# Altium schematic sheet JW302-01-V01_A0.SchDoc (continued)

## Library data of the parts
B1-B4 = 贴片磁珠 0603 600Ω@100MHz ±25% 1.3A 150mΩ: 贴片磁珠; 参考单价: 0.043424; 品牌: muRata(村田); 型号: BLM18KG601SN1D; Component Kind: Standard; Supplier Part: C85833; Library Name: 常用电阻电容库-20240318.SchLib
C1 C7 C12 = 贴片电容 0402 10uF ±20% 10V X5R: 贴片电容; 参考单价: 0.0457; 品牌: 村田; 型号: GRM155R61A106ME44D; 替换料: C408132: GRM155R61A106ME11D; Component Kind: Standard; Supplier Part: C77000; Library Name: 常用电阻电容库-20240318.SchLib
C2 C5 C8 C14 C16 C18 C20 C23 C27-C29 C39 C41 C52 C55 C62 C68 = 贴片电容 0402 100nF ±10% 50V X7R: 贴片电容; 参考单价: 0.010063; 品牌: 村田; 型号: GRM155R71H104KE14D; Component Kind: Standard; Supplier Part: C77020
  C29 C39 C41 C52 C55 C62: Library Name: LCR库-20240318.SchLib
  C2 C5 C8 C14 C16 C18 C20 C23 C27 C28 C68: Library Name: 常用电阻电容库-20240318.SchLib
C3 = 贴片电容 0402 1.8pF ±0.1pF 50V C0G: 贴片电容; 参考单价: 0.081481; 品牌: 村田; 型号: GJM1555C1H1R8BB01D; Component Kind: Standard; Library Name: LCR库-20240318.SchLib; Supplier Part: C76902
C4 = 贴片电容 0402 1.5pF ±0.1pF 50V C0G: 贴片电容; 参考单价: 0.0553; 品牌: 村田; 型号: GJM1555C1H1R5BB01D; Component Kind: Standard; Library Name: LCR库-20240318.SchLib; Supplier Part: C76900
C6 C22 C53 = 贴片电容 0603 22uF ±20% 10V X5R: 贴片电容; 参考单价: 0.060427; 品牌: muRata(村田); 型号: GRM188R61A226ME15D; 替代料: C86295：CL10A226MP8NUNE; Component Kind: Standard; Supplier Part: C84419; Library Name: 常用电阻电容库-20240318.SchLib
C9 C63 = 贴片电容 0402 4.7uF ±10% 10V X5R: 贴片电容; 参考单价: 0.051144; 品牌: 村田; 型号: GRM155R61A475KEAAD; Component Kind: Standard; Library Name: LCR库-20240318.SchLib; Supplier Part: C77004
C10 C11 = 贴片电容 0402 15pF ±5% 50V C0G: 贴片电容; 参考单价: 0.0085; 品牌: 村田; 型号: GRM1555C1H150JA01D; Component Kind: Standard; Library Name: LCR库-20240318.SchLib; Supplier Part: C76950
C13 C15 C25 C35 C36 C59 = 贴片电容 0402 1uF ±10% 25V X5R: 贴片电容; 参考单价: 0.0248; 品牌: 村田; 型号: GRM155R61E105KA12D; Component Kind: Standard; Supplier Part: C77009
  C35 C36 C59: Library Name: LCR库-20240318.SchLib
  C13 C15 C25: Library Name: 常用电阻电容库-20240318.SchLib
C17 C66 C67 = 贴片电容 0402 10nF ±10% 50V X7R: 贴片电容; 参考单价: 0.008376; 品牌: 村田; 型号: GRM155R71H103KA88D; Component Kind: Standard; Supplier Part: C77019; Library Name: 常用电阻电容库-20240318.SchLib
C19 = 贴片电容 0603 10uF ±20% 35V X5R: 贴片电容; 参考单价: 0.133331; 品牌: muRata(村田); 型号: GRM188R6YA106MA73D; Component Kind: Standard; Supplier Part: C194427; Library Name: 常用电阻电容库-20240318.SchLib
C21 = 贴片电容 1206 100uF ±20% 6.3V X5R: 贴片电容; 参考单价: 0.3025; 品牌: SAMSUNG(三星); 型号: CL31A107MQHNNNE; Component Kind: Standard; Library Name: LCR库-20240318.SchLib; Supplier Part: C15008
C24 = 贴片电容 0402 470pF ±5% 50V C0G: 贴片电容; 参考单价: 0.012183; 品牌: 村田; 型号: GRM1555C1H471JA01D; Component Kind: Standard; Supplier Part: C76971; Library Name: 常用电阻电容库-20240318.SchLib
C26 = 贴片电容 0402 2.2uF ±10% 10V X5R: 贴片电容; 参考单价: 0.024506; 品牌: 村田; 型号: GRM155R61A225KE95D; Component Kind: Standard; Supplier Part: C77002; Library Name: 常用电阻电容库-20240318.SchLib
C30 C42 = 贴片电容 0402 22pF ±5% 50V C0G: 贴片电容; 参考单价: 0.008376; 品牌: 村田; 型号: GRM1555C1H220JA01D; Component Kind: Standard; Supplier Part: C76960; Library Name: 常用电阻电容库-20240318.SchLib
C31 C32 C47 C48 C60 = 贴片电容 0603 10uF ±10% 16V X5R: 贴片电容; 参考单价: 0.1745; 品牌: muRata(村田); 型号: GRM188R61C106KAALD; Component Kind: Standard; Library Name: LCR库-20240318.SchLib; Supplier Part: C86275，C711098
C33 C34 C49 C50 = 贴片电容 0603 22uF ±20% 10V X5R: 贴片电容; 参考单价: 0.060427; 品牌: muRata(村田); 型号: GRM188R61A226ME15D; 替换料: C86295：CL10A226MP8NUNE; Component Kind: Standard; Library Name: LCR库-20240318.SchLib; Supplier Part: C84419
C37 C38 C43-C46 C54 = 贴片电容 0402 1uF ±10% 10V X7S: 贴片电容; 参考单价: 0.058339; 品牌: 村田; 型号: GCM155C71A105KE38D; Component Kind: Standard; Library Name: LCR库-20240318.SchLib; Supplier Part: C126521
C40 C51 = 贴片电容 0402 10uF ±20% 10V X5R: 贴片电容; 参考单价: 0.0457; 品牌: 村田; 型号: GRM155R61A106ME44D; 替代料: C408132: GRM155R61A106ME11D; Component Kind: Standard; Library Name: LCR库-20240318.SchLib; Supplier Part: C77000
C56-C58 C61 C64 C65 = 贴片电容 1206 22uF ±10% 16V X5R: 贴片电容; 参考单价: 0.123884; 品牌: SAMSUNG(三星); 型号: CL31A226KOHNNNE; Component Kind: Standard; Library Name: LCR库-20240318.SchLib; Supplier Part: C90146
CARD1 = TF-021B-H265 抽拉式 MicroSD卡(TF卡) 卡座: TF卡座; Manufacturer: SOFNG(硕方); Add into BOM: yes; Card Connection Mode: 拔插式; Connector Type: 卡座; Maximum Body Height: 2.5mm; 参考单价: 0.737352; Card Type: MicroSD卡(TF卡); Component Kind: Standard; Convert to PCB: yes; Datasheet: https://atta.szlcsc.com/upload/public/pdf/source/20200318/C498185_790264C8C4D0E25CCBD64FEBD13A33FF.pdf; Device: TF-021B-H265; JLCPCB Part Class: Extended Part; LCSC Part Name: 拔插式 MicroSD卡(TF卡) 卡座; Manufacturer Part: TF-021B-H265; Operating Temperature Range: -25℃~+75℃; Supplier: LCSC; Supplier Footprint: SMD; Supplier Part: C498185; Symbol: TF-021B-H265; 品牌: SOFNG(硕方); 型号: TF-021B-H265; Library Name: JTL-20240318.SchLib
CH+ CH+. CH- CH-. HOT+ HOT- NTC1 NTC2 NTC- = 1PIN PAD: 引线焊盘; Component Kind: Standard; Library Name: JTL-20240318.SchLib
CN1 = 排线座 1.0-5P WT SH系列 1x5P 卧贴: 排线端子座; Manufacturer: SHOU HAN(首韩); Add into BOM: yes; Number of PINs Per Row: 5; Pins Structure: 1x5P; Pitch: 1mm; 参考单价: 0.18202; Component Kind: Standard; Convert to PCB: yes; Current Rating (Max): -; Datasheet: https://atta.szlcsc.com/upload/public/pdf/source/20211019/F6C8BB00F705446EDA229495354B330C.pdf; Device: 1.0-5P WT; JLCPCB Part Class: Extended Part; LCSC Part Name: 1.0-5P WT; Manufacturer Part: 1.0-5P WT; Mounting Style: 卧贴; Number of Pins: 5P; Number of Rows: 1; Operating Temperature Range: -; Reference Series: -; Row Spacing: -; Supplier: LCSC; Supplier Footprint: SMD,P=1mm,卧贴; Supplier Part: C2906271; Symbol: 1.0-5P WT; 品牌: SHOU HAN(首韩); 型号: 1.0-5P WT; Library Name: JTL-20240318.SchLib
CN2 = PAD_4PIN: 贴片插座; Value: MX1.25-3P 卧式; Component Kind: Standard; Library Name: JTL-20240318.SchLib
CN3 = 排线座  ZX-MX1.25-3PWT MX系列 1x3P 卧贴: 排线端子座; Manufacturer: Megastar(兆星); Add into BOM: yes; Current Rating (Max): 1A; Number of PINs Per Row: 3; Pins Structure: 1x3P; Pitch: 1.25mm; 参考单价: 0.147155; Component Kind: Standard; Contact Material: 黄铜; Contact Plating: 锡; Convert to PCB: yes; Datasheet: https://atta.szlcsc.com/upload/public/pdf/source/20230619/F53A6BBA29708C54D1E94831F29660FB.pdf; Device: ZX-MX1.25-3PWT; LCSC Part Name: 1x3P 间距:1.25mm 卧贴; Manufacturer Part: ZX-MX1.25-3PWT; Mounting Style: 卧贴; Number of Pins: 3P; Number of Rows: 1; Operating Temperature Range: -25℃~+85℃; Reference Series: -; Row Spacing: -; Supplier: LCSC; Supplier Footprint: SMD,P=1.25mm,卧贴; Supplier Part: C7430469; Symbol: ZX-MX1.25-3PWT; 品牌: Megastar(兆星); 型号: ZX-MX1.25-3PWT; Library Name: JTL-20240318.SchLib
CN4 CN5 = 排线座 1.0-2P WT SH系列 1x2P 卧贴: 排线端子座; Manufacturer: SHOU HAN(首韩); Add into BOM: yes; Current Rating (Max): 500mA; Number of PINs Per Row: 2; Pins Structure: 1x2P; Pitch: 1mm; 参考单价: 0.13908; Component Kind: Standard; Convert to PCB: yes; Datasheet: https://atta.szlcsc.com/upload/public/pdf/source/20211019/B5D67F44D8FE6623BC62180783B545E4.pdf; Device: 1.0-2P WT; JLCPCB Part Class: Extended Part; LCSC Part Name: 1.0-2P WT; Manufacturer Part: 1.0-2P WT; Mounting Style: 卧贴; Number of Pins: 2P; Number of Rows: 1; Operating Temperature Range: -25℃~+85℃; Reference Series: -; Row Spacing: -; Supplier: LCSC; Supplier Footprint: SMD,P=1mm,卧贴; Supplier Part: C2906268; Symbol: 1.0-2P WT; 品牌: SHOU HAN(首韩); 型号: 1.0-2P WT; Library Name: JTL-20240318.SchLib
FPC1 = FPC连接器 AFC01-S22FCA-00 SMD,P=0.5mm,卧贴 翻盖式 下接 22P: FPC连接器; Manufacturer: JS(钜硕电子); Add into BOM: yes; FFC, FCB Thickness: 0.3mm; Locking Feature: 翻盖式; Number of Contacts: 22P; Pitch: 0.5mm; 参考单价: 0.3727; Component Kind: Standard; Contact Type: 下接; Convert to PCB: yes; Datasheet: https://atta.szlcsc.com/upload/public/pdf/source/20190816/C413942_2A72D1AC756EAE17EEDF756A339D4500.pdf; Device: AFC01-S22FCA-00; Height Above Board: 2mm; JLCPCB Part Class: Extended Part; LCSC Part Name: 间距:0.5mm P数:22P 翻盖式 下接; Manufacturer Part: AFC01-S22FCA-00; Mounting Type: 卧贴; Supplier: LCSC; Supplier Footprint: SMD,P=0.5mm,卧贴; Supplier Part: C262668; Symbol: AFC01-S22FCA-00; 品牌: JS(钜硕电子); 型号: AFC01-S22FCA-00; Library Name: JTL-20240318.SchLib
L1 = 贴片电感 0402 2.7nH ±0.3nH 800mA 100mΩ: 贴片电感; 参考单价: 0.045; 品牌: muRata(村田); 型号: LQG15HN2N7S02D; 替代料: C27123: SDCL1005C2N7STDF; Component Kind: Standard; Library Name: LCR库-20240318.SchLib; Supplier Part: C113054
L2 = 贴片电感 0603 10uH ±20% 100mA 1.365Ω: 贴片电感; 参考单价: 0.309131; 品牌: muRata(村田); 型号: LQM18DN100M70L; Component Kind: Standard; Library Name: LCR库-20240318.SchLib; Supplier Part: C713281
L3 L4 = 功率电感 FXL0420-4R7-M SMD,4.2x4.4mm 4.7uH ±20% 2.8A 3A 105mΩ: 功率电感; Manufacturer: cjiang(长江微电); Add into BOM: yes; DC Resistance (DCR): 105mΩ; Saturation Current (Isat): 3A; Tolerance: ±20%; Type: 一体成型电感; Value: 4.7uH; 参考单价: 0.3425; Component Kind: Standard; Convert to PCB: yes; Datasheet: https://atta.szlcsc.com/upload/public/pdf/source/20240426/3189EA8206330E2108542F9E34E540E7.pdf; Device: FXL0420-4R7-M; JLCPCB Part Class: Extended Part; LCSC Part Name: 4.7uH ±20% 3A; Library Name: LCR库-20240318.SchLib; Manufacturer Part: FXL0420-4R7-M; Supplier: LCSC; Supplier Footprint: SMD,4.2x4.4mm; Supplier Part: C167208; Symbol: FXL0420-4R7-M; 品牌: cjiang(长江微电); 型号: FXL0420-4R7-M
L5 = 功率电感 FXL1350-1R0-M SMD,12.6x13.5mm 1uH ±20% 28A 35A,2.2mΩ: 功率电感; Manufacturer: cjiang(长江微电); Add into BOM: yes; DC Resistance (DCR): 2.2mΩ; Rated Current: 26A; Tolerance: ±20%; Type: 一体成型电感; Value: 1uH; 参考单价: 1.73; Component Kind: Standard; Convert to PCB: yes; Datasheet: https://atta.szlcsc.com/upload/public/pdf/source/20240426/3189EA8206330E2108542F9E34E540E7.pdf; Device: FXL1350-1R0-M; JLCPCB Part Class: Extended Part; LCSC Part Name: 1uH ±20% 35A; Library Name: LCR库-20240318.SchLib; Manufacturer Part: FXL1350-1R0-M; Supplier: LCSC; Supplier Footprint: SMD,12.6x13.5mm; Supplier Part: C526011; Symbol: FXL1350-1R0-M; 品牌: cjiang(长江微电); 型号: FXL1350-1R0-M
NTC3 = NTC B57332V5103F360 0603 10KΩ±1%β(25/85)3435K,β(25/50)3380K: NTC; Manufacturer: TDK; Add into BOM: yes; B Constant (25℃/85℃): 3435K; B Constant (25℃/100℃): 3455K; B Constant Tolerance: ±1%; Power: 180mW; Resistance @ 25℃: 10kΩ; 参考单价: 0.232655; B Constant (25℃/75℃): -; Component Kind: Standard; Convert to PCB: yes; Datasheet: https://atta.szlcsc.com/upload/public/pdf/source/20180725/C209893_3E20A4FCEBCC841838CF028DBFDEBAEE.pdf; Device: B57332V5103F360; JLCPCB Part Class: Extended Part; LCSC Part Name: 10kΩ 电阻精度:±1% 3380K; Library Name: LCR库-20240318.SchLib; Manufacturer Part: B57332V5103F360; Operating Temperature: -40℃~+150℃; Resistance Tolerance: ±1%; Steady State Current (Max): -; Supplier: LCSC; Supplier Part: C209893; Symbol: B57332V5103F360; 品牌: TDK; 型号: B57332V5103F360
Q1-Q3 Q6 Q7 = PMOS SK2301AAT SOT-523-3 -20V,-2.1A,130mΩ@-4.5V -2.3A -620mV@-250uA: PMOS; Manufacturer: SHIKUES(时科); Add into BOM: yes; Continuous Drain Current (Id): 2.1A; Drain Source On Resistance (RDS(on)@Vgs,Id): 130mΩ@4.5V,2.3A; Drain Source Voltage (Vdss): 20V; Gate Threshold Voltage (Vgs(th)@Id): 620mV@250uA; Input Capacitance (Ciss@Vds): 290pF@10V; Power Dissipation (Pd): 350mW; Reverse Transfer Capacitance (Crss@Vds): 29pF@10V; Total Gate Charge (Qg@Vgs): 3.9nC@4.5V; Type: 1个P沟道; 参考单价: 0.132; Component Kind: Standard; Convert to PCB: yes; Datasheet: https://atta.szlcsc.com/upload/public/pdf/source/20231124/8C624ED955EAB991419DA0DB728EE27F.pdf; Device: SK2301AAT; JLCPCB Part Class: Extended Part; LCSC Part Name: 1个P沟道 耐压:20V 电流:2.1A; Manufacturer Part: SK2301AAT; Operating Temperature: -55℃~+150℃; Supplier: LCSC; Supplier Footprint: SOT-523; Supplier Part: C5334586; Symbol: SK2301AAT; 品牌: SHIKUES(时科); 型号: SK2301AAT; Library Name: JTL-20240318.SchLib
Q4 Q5 = NMOS JMSL0302AG DFN-5 30V,145A,1.2mΩ@10V 20A,1.7V@250uA: NMOS; Manufacturer: JJW(捷捷微); Add into BOM: yes; Continuous Drain Current (Id): 178A; Drain Source On Resistance (RDS(on)@Vgs,Id): 1.3mΩ@10V,20A; Drain Source Voltage (Vdss): 30V; Input Capacitance (Ciss@Vds): 2.975nF@15V; Power Dissipation (Pd): 78W; Reverse Transfer Capacitance (Crss@Vds): 117pF@15V; Total Gate Charge (Qg@Vgs): 39nC@10V; 参考单价: 0.9; Component Kind: Standard; Convert to PCB: yes; Datasheet: https://atta.szlcsc.com/upload/public/pdf/source/20210907/947B1805E145B198DD3F472E870FBC49.pdf; Device: JMSL0302AG; Gate Threshold Voltage (Vgs(th)@Id): 1.7V@250uA; JLCPCB Part Class: Extended Part; LCSC Part Name: N沟道,电流:178A,耐压:30V; Manufacturer Part: JMSL0302AG; Operating Temperature: -55℃~+150℃; Supplier: LCSC; Supplier Footprint: DFN-5(5.1x6.1); Supplier Part: C2890410; Symbol: JMSL0302AG; 品牌: JJW(捷捷微); 型号: JMSL0302AG; Library Name: JTL-20240318.SchLib
Q8 Q9 = NMOS CJ1012 SOT-523-3 20V,0.5A,700mΩ@4.5V 0.5A,1.2V@250uA: NMOS; Manufacturer: CJ(江苏长电/长晶); Add into BOM: yes; Continuous Drain Current (Id): 500mA; Drain Source Voltage (Vdss): 20V; Gate Threshold Voltage (Vgs(th)@Id): 1.2V; Input Capacitance (Ciss@Vds): 100pF; Reverse Transfer Capacitance (Crss@Vds): 12pF; Symbol: CJ1012_C2991967; Total Gate Charge (Qg@Vgs): 750nC; Type: 1个N沟道; 参考单价: 0.176; Component Kind: Standard; Convert to PCB: yes; Datasheet: https://atta.szlcsc.com/upload/public/pdf/source/20220411/AA10FFCA313DDFB0AC016DEA89735A25.pdf; Device: CJ1012; JLCPCB Part Class: Extended Part; LCSC Part Name: 1个N沟道 耐压:20V 电流:500mA; Manufacturer Part: CJ1012; Supplier: LCSC; Supplier Footprint: SOT-523; Supplier Part: C2991967; 品牌: CJ(江苏长电/长晶); 型号: CJ1012; Library Name: JTL-20240318.SchLib
R1 R2 R4 R6 R8 R43 R45 = 厚膜电阻 0402 4.7KΩ ±1%: 贴片电阻; 参考单价: 0.0023; 品牌: 国巨; 型号: RC0402FR-074K7L; Component Kind: Standard; Library Name: LCR库-20240318.SchLib; Supplier Part: C105871,C2935240
R3 R15-R17 R23-R25 R42 R46 R48 R62 = 厚膜电阻 0402 10KΩ ±1%: 贴片电阻; 参考单价: 0.003; 品牌: 国巨; 型号: RC0402FR-0710KL; Component Kind: Standard; Supplier Part: C60490; Library Name: 常用电阻电容库-20240318.SchLib
R5 R7 R9-R14 R26-R32 R49 R50 = 厚膜电阻 0402 0Ω ±1%: 贴片电阻; 参考单价: 0.003; 品牌: 国巨; 型号: RC0402FR-070RL; Component Kind: Standard; Library Name: LCR库-20240318.SchLib; Supplier Part: C106231
R18 = 厚膜电阻 0402 470Ω ±1%: 贴片电阻; 参考单价: 0.003; 品牌: 国巨; 型号: RC0402FR-07470RL; Component Kind: Standard; Library Name: LCR库-20240318.SchLib; Supplier Part: C114877
R19 R22 = 厚膜电阻 0402 30KΩ ±1%: 贴片电阻; 参考单价: 0.003; 品牌: 国巨; 型号: RC0402FR-0730KL; Component Kind: Standard; Library Name: LCR库-20240318.SchLib; Supplier Part: C138008
R20 R21 R36 R51 R58 = 厚膜电阻 0402 1KΩ ±1%: 贴片电阻; 参考单价: 0.003; 品牌: 国巨; 型号: RC0402FR-071KL; Component Kind: Standard; Supplier Part: C106235、C2935237
  R20 R21: Library Name: LCR库-20240318.SchLib
  R36 R51 R58: Library Name: 常用电阻电容库-20240318.SchLib
R33 = 厚膜电阻 0402 66.5KΩ ±1%: 贴片电阻; 参考单价: 0.003; 品牌: 国巨; 型号: RC0402FR-0766K5L; Component Kind: Standard; Library Name: LCR库-20240318.SchLib; Supplier Part: C327329
R34 = 厚膜电阻 0402 100Ω ±1%: 贴片电阻; 参考单价: 0.003; 品牌: 国巨; 型号: RC0402FR-07100RL; Component Kind: Standard; Library Name: LCR库-20240318.SchLib; Supplier Part: C106232、C2935235
R35 R38 R39 = 厚膜电阻 0402 1MΩ ±1%: 贴片电阻; 参考单价: 0.0025; 品牌: 国巨; 型号: RC0402FR-071ML; Component Kind: Standard; Library Name: LCR库-20240318.SchLib; Supplier Part: C138033
R37 = 厚膜电阻 0402 12KΩ ±1%: 贴片电阻; 参考单价: 0.003; 品牌: 国巨; 型号: RC0402FR-0712KL; Component Kind: Standard; Supplier Part: C114760; Library Name: 常用电阻电容库-20240318.SchLib
R40 R53 R55 R59 = 厚膜电阻 0402 100KΩ ±1%: 贴片电阻; 参考单价: 0.003; 品牌: 国巨; 型号: RC0402FR-07100KL; Component Kind: Standard; Supplier Part: C60491; Library Name: 常用电阻电容库-20240318.SchLib
R41 R44 = 厚膜电阻 0402 1.2KΩ ±1%: 贴片电阻; 参考单价: 0.003; 品牌: 国巨; 型号: RC0402FR-071K2L; Component Kind: Standard; Library Name: LCR库-20240318.SchLib; Supplier Part: C138040
R47 = 厚膜电阻 0402 43KΩ ±1%: 贴片电阻; 参考单价: 0.003; 品牌: 国巨; 型号: RC0402FR-0743KL; Component Kind: Standard; Library Name: LCR库-20240318.SchLib; Supplier Part: C185415
R52 = 厚膜电阻 0402 13KΩ ±1%: 贴片电阻; 参考单价: 0.003; 品牌: 国巨; 型号: RC0402FR-0713KL; Component Kind: Standard; Library Name: LCR库-20240318.SchLib; Supplier Part: C138057
R54 R57 R60 = 厚膜电阻 0402 2Ω ±1%: 贴片电阻; 参考单价: 0.005; 品牌: 国巨; 型号: RC0402FR-072RL; Component Kind: Standard; Library Name: LCR库-20240318.SchLib; Supplier Part: C477683
R56 = 合金电阻 1206 2mΩ ±1% 1W: 合金电阻; 参考单价: 0.4; 品牌: UNI-ROYAL(厚声); 型号: LR061WF200NT5E; Component Kind: Standard; Library Name: LCR库-20240318.SchLib; Supplier Part: C2779565
R61 = 厚膜电阻 0402 36KΩ ±1%: 贴片电阻; 参考单价: 0.003; 品牌: 国巨; 型号: RC0402FR-0736KL; Component Kind: Standard; Library Name: LCR库-20240318.SchLib; Supplier Part: C137999
R63 R64 = 厚膜电阻 0402 5.1KΩ ±1%: 贴片电阻; 参考单价: 0.003; 品牌: 国巨; 型号: RC0402FR-075K1L; Component Kind: Standard; Supplier Part: C105872; Library Name: 常用电阻电容库-20240318.SchLib
RF1 = IPEX-4 AIPEX-4 四代: IPEX-4; Manufacturer: JXTCONN(聚兴泰); Add into BOM: yes; Connector Type: 板端; Impedance: 50Ω; Interface: 内针; Number of Ports: 1; RF Series: IPEX; Component Kind: Standard; Convert to PCB: yes; Datasheet: https://atta.szlcsc.com/upload/public/pdf/source/20241024/A02E6047846CDEB8A84968A5E27EAE01.pdf; Device: AIPEX-4; JLCPCB Part Class: Extended Part; LCSC Part Name: 内针 IPEX; Manufacturer Part: AIPEX-4; Operating Temperature Range: -40℃~+85℃; Supplier: LCSC; Supplier Footprint: SMD; Supplier Part: C41432125; Symbol: AIPEX-4; Value: 6GHz; Library Name: JTL-20240318.SchLib
SW1 SW2 = 轻触开关 TS-1145A-B-A 3.2x4.2x2.5平脚160gf白色按钮 10万: 轻触开关; Manufacturer: XKB Connectivity(中国星坤); Actuator Style: 椭圆形按钮; Actuator/Cap Color: 白色; Add into BOM: yes; Circuit: 单刀单掷; Contact Current: 50mA; Mechanical Life: 10万次; Operating Force: 160gf; Strike Gundam: 不带; Switch Height: 2.5mm; Switch Length: 4.2mm; Switch Width: 3.2mm; Voltage Rating (DC): 12V; With Lamp: 无; 参考单价: 0.133784; 品牌: XKB Connection(中国星坤); Component Kind: Standard; Convert to PCB: yes; Datasheet: https://atta.szlcsc.com/upload/public/pdf/source/20211011/FC00869C3CE33BCCD344D072F551E76B.pdf; Device: TS-1145A-B-A; Insulation Resistance: -; JLCPCB Part Class: Extended Part; LCSC Part Name: 4.2*3.2*2.5mm 立贴 轻触开关; Manufacturer Part: TS-1145A-B-A; Mounting Style: 立贴; Operating Temperature: -30℃~+85℃; Supplier: LCSC; Supplier Footprint: SMD; Supplier Part: C318904; Symbol: TS-1145A-B-A; 型号: TS-1145A-B-A; Library Name: JTL-20240318.SchLib
SW3-SW5 = 轻触开关 TS-1187A-C-E-B 5.1x5.1x2.5 贴片260gf 金属头 防水 10万: 开关; Manufacturer: XKB Connectivity(中国星坤); Actuator Style: 圆形按钮; Actuator/Cap Color: 金色; Add into BOM: yes; Circuit: 单刀单掷; Contact Current: 50mA; Mechanical Life: 10万次; Operating Force: 260gf; Strike Gundam: 不带; Switch Height: 2.5mm; Switch Length: 5.1mm; Voltage Rating (DC): 12V; With Lamp: 无; 参考单价: 0.13205; Component Kind: Standard; Convert to PCB: yes; Datasheet: https://atta.szlcsc.com/upload/public/pdf/source/20200227/C318890_053E50208A88A7BA1965D8746000774E.pdf; Device: TS-1187A-C-E-B; Insulation Resistance: -; JLCPCB Part Class: Extended Part; LCSC Part Name: 5.1*5.1*2.5mm 立贴 轻触开关; Manufacturer Part: TS-1187A-C-E-B; Mounting Style: 立贴; Operating Temperature: -30℃~+85℃; Supplier: LCSC; Supplier Footprint: SMD; Supplier Part: C318887; Switch Width: 5.1mm; Symbol: TS-1187A-C-E-B; 品牌: XKB Connectivity(中国星坤); 型号: TS-1187A-C-E-B; Library Name: JTL-20240318.SchLib
TF1 = TFcard: TF卡; Component Kind: Standard; Library Name: JTL-20240318.SchLib
TP1 TP2 = TESTPOINT: 测试点; Component Kind: Standard; Library Name: JTL-20240318.SchLib
TVS1 = 单向TVS管 SMBJ15A SMB 击穿电压:16.7V Ipp:24.6A: TVS管单向; Manufacturer: 晶导微电子; Add into BOM: yes; Breakdown Voltage: 16.7V; Device: SMBJ15A_C188412; Maximum Clamping Voltage: 24.4V; Peak Pulse Current (Ipp)@10/1000us: 24.6A; Peak Pulse Power Dissipation (Ppp)@10/1000us: 600W; Reverse Leakage Current (Ir): 1uA; Reverse Stand-Off Voltage (Vrwm): 15V; 参考单价: 0.126; Component Kind: Standard; Convert to PCB: yes; Datasheet: https://atta.szlcsc.com/upload/public/pdf/source/20190425/C382838_2D6FAEBA99E8AAE51AD263CFC481B4D8.pdf; JLCPCB Part Class: Extended Part; LCSC Part Name: SMBJ15A; Manufacturer Part: SMBJ15A; Supplier: LCSC; Supplier Footprint: SMB(DO-214AA); Supplier Part: C188412; Symbol: SMBJ15A_C188412; Type: TVS; 品牌: 晶导微电子; 型号: SMBJ15A; Library Name: JTL-20240318.SchLib
TVS2 TVS4 = 双向TVS管 SMF3.3CA SOD-123 双向 击穿电压:3.5V Ipp:40A: TVS管双向; 参考单价: 0.169; 品牌: BORN(伯恩半导体); Component Kind: Standard; Supplier Part: C6165153; 型号: SMF3.3CA; Library Name: JTL-20240318.SchLib
TVS3 = 双向TVS管 PESD3V3S1BA-N SOD-523 双向 击穿电压: 4V,IPP:10A: TVS管双向; BOM_Manufacturer: BORN(伯恩半导体); SMT Type: Extend; 参考单价: 0.11952; BOM_LCSC Assembly: Yes; BOM_Manufacturer Part: PESD3V3S1BA-N; BOM_Supplier: LCSC; BOM_Supplier Part: C316037; Component Kind: Standard; image: https://item.szlcsc.com/297725.html?t=1713508341957&s=1713508341957; spicePre: D; spiceSymbolName: PESD3V3S1BA-N; Supplier Part: C316037; 品牌: BORN(伯恩半导体); 型号: PESD3V3S1BA-N; Library Name: JTL-20240318.SchLib
TVS5 = 双向TVS管 SMF5.0CA SOD-123 双向 击穿电压:6.4V Ipp:21.7A: TVS管双向; 参考单价: 0.077563; 品牌: Slkor(萨科微); Component Kind: Standard; Supplier Part: C920175; 型号: SMF5.0CA; Library Name: JTL-20240318.SchLib
U1 = IO扩展芯片 TCA9555RTWR VQFN-24-EP(4x4) I2C&SMBus 16 有中断输出: 芯片-扩展芯片; Manufacturer: UMW(友台半导体); Add into BOM: yes; 参考单价: 1.29; Component Kind: Standard; Convert to PCB: yes; Datasheet: https://atta.szlcsc.com/upload/public/pdf/source/20241224/B6E35211EA00A4E49B1EFFFCEC0A8852.pdf; Device: TCA9555RTWR(UMW); LCSC Part Name: I/O扩展器; Manufacturer Part: TCA9555RTWR(UMW); Supplier: LCSC; Supplier Footprint: VQFN-24-EP(4x4); Supplier Part: C42420609; Symbol: TCA9555RTWR(UMW); 品牌: UMW(友台半导体); 型号: TCA9555RTWR(UMW); Library Name: JTL-20240318.SchLib
U2 = SOC ESP32-S3FN8 QFN-56(7x7) 8MB(OSPI): 芯片-SOC; Manufacturer: ESPRESSIF(乐鑫); Add into BOM: yes; Symbol: ESP32-S3(FN8); 参考单价: 20.5; Component Kind: Standard; Convert to PCB: yes; Datasheet: https://item.szlcsc.com/datasheet/ESP32-S3FN8/3198294.html; Device: ESP32-S3FN8; JLCPCB Part Class: Extended Part; LCSC Part Name: ESP32-S3FN8; Manufacturer Part: ESP32-S3FN8; PCB3D: QFN-56_L7.0-W7.0-P0.40-TL-EP4.0; Supplier: LCSC; Supplier Footprint: QFN-56-EP(7x7); Supplier Part: C2913196; 品牌: ESPRESSIF(乐鑫); 型号: ESP32-S3FN8; Library Name: JTL-20240318.SchLib
U3 = 复位芯片 MAX809S SOT23-3 1V~5.5V 2.93V 低电平有效: 复位芯片; Manufacturer: UMW(友台半导体); Add into BOM: yes; Manual Reset: 无; Number of Voltages Monitored: 1; Output Type: 推挽; Reset Active Level: 低电平有效; Reset Timeout: 140ms; Threshold Voltage: 2.93V; 参考单价: 0.1246; ChipType: -; Component Kind: Standard; Convert to PCB: yes; Datasheet: https://atta.szlcsc.com/upload/public/pdf/source/20231109/58A364AAEE430663477EC6AD90E1BF7C.pdf; Device: MAX809S; Input Voltage: 1V~5.5V; JLCPCB Part Class: Extended Part; LCSC Part Name: MAX809S; Manufacturer Part: MAX809S; Monitoring voltage: -; Operating Temperature: -40℃~+85℃@(TA); PCB3D: SOT-23-3P_L2.9-W1.3-H1.0-LS2.4-P0.95; Supplier: LCSC; Supplier Footprint: SOT-23; Supplier Part: C347371; Symbol: MAX809S; Watchdog: 无; 品牌: UMW(友台半导体); 型号: MAX809S; Library Name: JTL-20240318.SchLib
U4 = NOR FLASH GD25Q32CSIGR SOIC-8 32Mbit 120MHz 2.7V~3.6V: 芯片-NOR FLASH; Manufacturer: GigaDevice(兆易创新); Add into BOM: yes; Clock Frequency: 120MHz; Data Retention - TDR (Year): 20年; Interface: SPI; Memory Size: 32Mbit; Page Programming Time (Tpp): 600us; Program / Erase Cycles: 100000次; Standby Supply Current: 1uA; Symbol: GD25Q32CSIG_C318845; 参考单价: 2.1; Block Erase Time(tBE): -; Component Kind: Standard; Convert to PCB: yes; Datasheet: https://atta.szlcsc.com/upload/public/pdf/source/20181022/C318845_3877075FFB3D72AEC3C7BAC6BD27C028.pdf; Device: GD25Q32CSIGR; JLCPCB Part Class: Extended Part; LCSC Part Name: GD25Q32CSIGR; Manufacturer Part: GD25Q32CSIGR; Operating Temperature: -40℃~+85℃; Supplier: LCSC; Supplier Footprint: SOIC-8-208mil; Supplier Part: C318845; Voltage - Supply: 2.7V~3.6V; 品牌: GigaDevice(兆易创新); 型号: GD25Q32CSIGR; Library Name: JTL-20240318.SchLib
U5 = LDO MD7612A33PA1 SOT-89-3 40V,3.3V,2% 1A: LDO; Manufacturer: 明达微; Add into BOM: yes; Output Current: 1A; Component Kind: Standard; Convert to PCB: yes; Datasheet: https://atta.szlcsc.com/upload/public/pdf/source/20220309/DA883751BDA22ECB80106D5B2749DB60.pdf; Device: MD7612A33PA1; JLCPCB Part Class: Extended Part; LCSC Part Name: MD7612A33PA1; Manufacturer Part: MD7612A33PA1; Supplier: LCSC; Supplier Footprint: SOT-89-3; Supplier Part: C2978350; Symbol: MD7612A33PA1; Library Name: JTL-20240318.SchLib
U6 = RAM ESP-PSRAM64H SOIC-8 64Mbit 133MHz 2.7v~3.6V: 芯片-RAM; Manufacturer: ESP; Add into BOM: yes; Clock Frequency: 133MHz; Interface: SPI; Memory Size: 64Mbit; 参考单价: 3.8; 品牌: GigaDevice(兆易创新); Component Kind: Standard; Convert to PCB: yes; Datasheet: https://atta.szlcsc.com/upload/public/pdf/source/20181022/C318845_3877075FFB3D72AEC3C7BAC6BD27C028.pdf; Device: ESP-PSRAM64H; JLCPCB Part Class: Extended Part; LCSC Part Name: ESP-PSRAM64H; Manufacturer Part: ESP-PSRAM64H; Operating Temperature: -40℃~+85℃; Supplier: LCSC; Supplier Footprint: SOIC-8; Supplier Part: /; Symbol: ESP-PSRAM64H; Voltage - Supply: 2.7V~3.6V; 型号: ESP-PSRAM64H; Library Name: JTL-20240318.SchLib
U7 U10 = DC/DC TPS563200DDCR SOT-23-6 降压型 vin:4.5~17V  2A 650Khz: DC/DC; Manufacturer: TI(德州仪器); Add into BOM: yes; Function: 降压型; Input Voltage: 4.5V~17V; Number of Outputs: 1; Output Current (Max): 3A; Output Type: 可调; Output Voltage: 760mV~7V; Switch tube (built-in/external): 内置; Switching Frequency: 650kHz; Synchronous Rectification: 是; Topology: 降压式; 参考单价: 0.4985; Component Kind: Standard; Convert to PCB: yes; Datasheet: https://www.ti.com/cn/lit/gpn/tps563200; Device: TPS563200DDCR; JLCPCB Part Class: Extended Part; LCSC Part Name: TPS563200DDCR; Manufacturer Part: TPS563200DDCR; Name: TPS563200DDCR; Operating Temperature: -40℃~+85℃@(TA); Supplier: LCSC; Supplier Footprint: SOT-23-THIN-6; Supplier Part: C97253; Symbol: TPS563200DDCR; 品牌: TI(德州仪器); 型号: TPS563200DDCR; Library Name: JTL-20240318.SchLib
U8 = 音频接口芯片 ES8374 QFN-28 I2S ADC&DAC 单声道 带功放: 芯片-音频; Manufacturer: Everest-semi(顺芯); Add into BOM: yes; Interface Type: I2S; Voltage - Supply: 3.3V~5V; 参考单价: 2.5; Component Kind: Standard; Convert to PCB: yes; Datasheet: https://atta.szlcsc.com/upload/public/pdf/source/20190124/C365738_8E1D5A7D83D8EEF54D159F3A3D06EC8D.pdf; Device: ES8374; JLCPCB Part Class: Extended Part; LCSC Part Name: 音频解码芯片; Manufacturer Part: ES8374; Supplier: LCSC; Supplier Footprint: QFN-28-EP(4x4); Supplier Part: C365738; Symbol: ES8374; 品牌: Everest-semi(顺芯); 型号: ES8374; Library Name: JTL-20240318.SchLib
U9 = 电压型电量计 CW2017BAAD TDFN-8 1至多节: SOC; Manufacturer: null; Contributor: LCSC; 参考单价: 2.3; 品牌: 赛微(CellWise); Component Kind: Standard; JLCPCB Part Class: Extended Part; Manufacturer Part: CW2017BAAD; spicePre: U; spiceSymbolName: CW2017BAAD; Supplier: LCSC; Supplier Part: C2688806; 型号: CW2017BAAD; Library Name: JTL-20240318.SchLib
U11 = LDO MD7612E33PC1 SOT89-5L 40V,3.3V,2% 1A: 芯片-LDO; Manufacturer: 明达微; Add into BOM: yes; MAX Output Current: 1A; 参考单价: 0.52; Component Kind: Standard; Convert to PCB: yes; Datasheet: https://atta.szlcsc.com/upload/public/pdf/source/20220701/805D1016DAE4A2E0F8A6128597252C08.pdf; Device: MD7612E33PC1; JLCPCB Part Class: Extended Part; LCSC Part Name: MD7612E33PC1; Manufacturer Part: MD7612E33PC1; Supplier: LCSC; Supplier Footprint: SOT89-5L; Symbol: MD7612E33PC1; 品牌: 明达微; 型号: MD7612E33PC1; Library Name: JTL-20240318.SchLib
U12 = 栅极驱动 LN8322DR DFN2*2-8 30V 半桥栅极驱动器: 芯片-栅极驱动; Manufacturer: natlinear(南麟); Add into BOM: yes; Driven Configuration: 半桥; Fall Time: 10ns; Load Type: MOSFET; Number of Drivers: 1; Propagation Delay tpHL: 13ns；12ns; Propagation Delay tpLH: 30ns；25ns; Rise Time: 16ns；15ns; Supply Voltage: 4.5V~13.2V; Whether the isolation: 非隔离; 参考单价: 0.4; Component Kind: Standard; Convert to PCB: yes; Datasheet: https://atta.szlcsc.com/upload/public/pdf/source/20220426/6EF480AD15A3630433E6A711445E3688.pdf; Device: LN8322DR; Feature: 欠压保护(UVP); JLCPCB Part Class: Extended Part; LCSC Part Name: LN8322DR; Manufacturer Part: LN8322DR; Operating Temperature: -40℃~+150℃@(Tj); Peak Output Current(sink): -; Peak Output Current(source): -; Supplier: LCSC; Supplier Footprint: DFN2*2-8; Symbol: LN8322DR; 品牌: natlinear(南麟); 型号: LN8322DR; Library Name: JTL-20240318.SchLib
U13 = IC线性恒流源 VAS1089 SOT23-5 可调线性恒流源 9-100mA: 线性恒流源; 参考单价: 0.2; 品牌: 奇力科技; Component Kind: Standard; 型号: VAS1089; Library Name: JTL-20240318.SchLib
U14 = INA199A1DCKR SOT-363(SC-70-6): 电流检测运放; 参考单价: 1.24; 品牌: TI(德州仪器); Component Kind: Standard; Supplier Part: C59135; 型号: INA199A1DCKR; Library Name: JTL-20240318.SchLib
X1 = 贴片无源晶振 TXM40M0004322HBCEO00T MD3225-4P 40MHz ±10ppm 15pF: 贴片无源晶振; Manufacturer: YJX(雅晶鑫); Add into BOM: yes; Crystal Type: 贴片晶振; Equivalent Series Resistance (ESR): 30Ω; Frequency Stability(Full temperature range): ±30ppm; Normal temperature Frequency Tolerance: ±10ppm; 参考单价: 0.2239; Component Kind: Standard; Convert to PCB: yes; Datasheet: https://atta.szlcsc.com/upload/public/pdf/source/20180910/C284179_2ACC6381EF288E18F29855CB4CF503EF.pdf; Device: TXM40M0004322HBCEO00T; JLCPCB Part Class: Extended Part; LCSC Part Name: 40MHz ±10ppm 15pF; Manufacturer Part: TXM40M0004322HBCEO00T; Operating Temperature: -40℃~+85℃; Supplier: LCSC; Supplier Footprint: SMD3225-4P; Supplier Part: C284179; Symbol: TXM40M0004322HBCEO00T; Value: 40MHz; 品牌: YJX(雅晶鑫); 型号: TXM40M0004322HBCEO00T; Library Name: JTL-20240318.SchLib
